# Altium schematic sheet RA_64pin_Local_Promotion_Board_4.SchDoc(RA_64pin_Local_Promotion_Board_4)
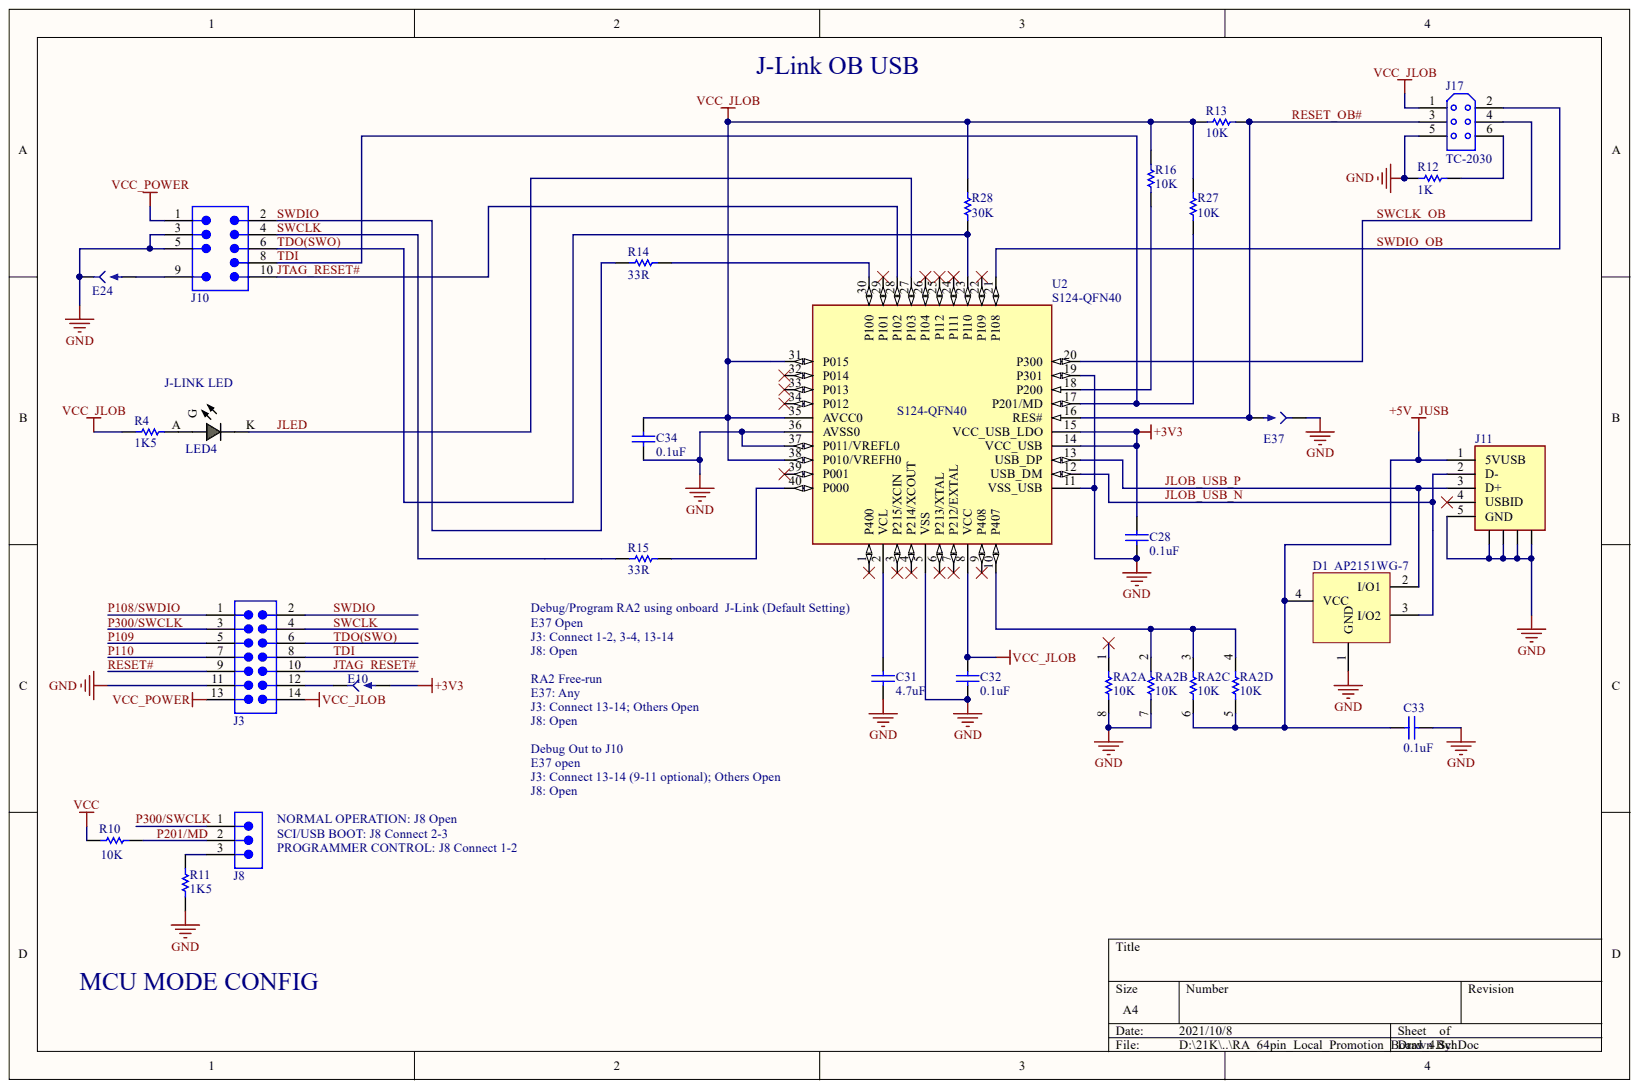
[diagram p0: RA_64pin_Local_Promotion_Board_4.SchDoc]

## Parts
C28  0.1uF  [CAPC0603-01]
C31  4.7uF  [CAPC0603-01]
C32  0.1uF  [CAPC0603-01]
C33  0.1uF  [CAPC0603-01]
C34  0.1uF  [CAPC0603-01]
D1  AP2151WG-7  [SOT-143B]
E10  Jumper Solder Bridging  [E_PadsSplit0805]  (value not drawn)  (no BOM)
E24  Jumper Solder Bridging  [E_PadsSplit0805]  (value not drawn)  (no BOM)
E37  Jumper Solder Bridging  [E_PadsSplit0805]  (value not drawn)  (no BOM)
J3  2.54mm 2*7  [HDR2X7]  (value not drawn)
J8  2.54mm 1x3  [FCI_6800-x03]  (value not drawn)
J10  1.27mm 2x5  [CON-10 C]  (value not drawn)
J11  UBAF-0608  [USBSB5_6]  (value not drawn)
J17  TC-2030  [JC-6pin-1.27 - duplicate]
LED4  #NAME?  [LC-0603_LED_S1]  (value not drawn)
R4  1K5  [RESC0603-01]
R10  10K  [RESC0603-01]
R11  1K5  [RESC0603-01]
R12  Resistance: 1K  [RESC0603-01]  (hidden comment: 4K7)
R13  Resistance: 10K  [RESC0603-01]  (hidden comment: 4K7)
R14  Resistance: 33R  [RESC0603-01]  (hidden comment: 150R)
R15  Resistance: 33R  [RESC0603-01]  (hidden comment: 150R)
R16  Resistance: 10K  [RESC0603-01]  (hidden comment: 4K7)
R27  Resistance: 10K  [RESC0603-01]  (hidden comment: 4K7)
R28  Resistance: 30K  [RESC0603-01]  (hidden comment: 4K7)
RA2  10K  [RESCAF0804]  (parts A,B,C,D)
U2  S124-QFN40  [RENESAS_P-HWQFN40-6x6-0.50]

## Nets
+3V3: C28.2 E10.2 U2.14(VCC_USB) U2.15(VCC_USB_LDO)
+5V_JUSB: C33.2 D1.4(VCC) J11.1(5VUSB) RA2.5 RA2.6
GND: C28.1 C31.1 C32.1 C33.1 C34.1 D1.1(GND) E24.1 E37.1 J3.11 J10.3 J10.5 J11.5(GND) J11.C1 J11.C2 J11.C3 J11.C4 J17.5 R11.1 R12.2 RA2.7 RA2.8 U2.5(VSS) U2.11(VSS_USB) U2.19(P301) U2.36(AVSS0) U2.37(P011/VREFL0)
VCC: R10.2
VCC_JLOB: C32.2 C34.2 J3.14 J17.1 R4.1 R13.2 R16.1 R27.1 R28.1 U2.8(VCC) U2.31(P015) U2.35(AVCC0) U2.38(P010/VREFH0)
VCC_POWER: J3.13 J10.1
JLED: LED4.K U2.27(P103)
JLOB_USB_N: D1.3(I/O2) J11.2(D-) U2.12(USB_DM)
JLOB_USB_P: D1.2(I/O1) J11.3(D+) U2.13(USB_DP)
JTAG_RESET#: J3.10 J10.10 U2.28(P102)
P108/SWDIO: J3.1
P109: J3.5
P110: J3.7
P201/MD: J8.2 R10.1
P300/SWCLK: J3.3 J8.1
RESET#: J3.9
RESET_OB#: E37.2 J17.3 R13.1 U2.16(RES#)
SWCLK: J3.4 J10.4 R15.1
SWCLK_OB: J17.4 U2.20(P300)
SWDIO: J3.2 J10.2 R14.1
SWDIO_OB: J17.2 U2.21(P108)
TDI: J3.8 J10.8 R27.2 U2.17(P201/MD)
TDO(SWO): J3.6 J10.6 R28.2 U2.23(P110)
NetC31_2: C31.2 U2.2(VCL)
NetE10_1: E10.1 J3.12
NetE24_2: E24.2 J10.9
NetJ8_3: J8.3 R11.2
NetJ17_6: J17.6 R12.1
NetLED4_A: LED4.A R4.2
NetR14_2: R14.2 U2.30(P100)
NetR15_2: R15.2 U2.40(P000)
NetR16_2: R16.2 U2.18(P200)
NetRA2_2: RA2.2 RA2.3 RA2.4 U2.10(P407)

Not connected: J11 4(USBID); RA2 1; U2 1(P400) 3(P215/XCIN) 4(P214/XCOUT) 6(P213/XTAL) 7(P212/EXTAL) 9(P408) 22(P109) 24(P111) 25(P112) 26(P104) 29(P101) 32(P014) 33(P013) 34(P012) 39(P001)

## Library data of the parts
C28 C32-C34 = CAP-0603-0.1uF: Ceramic Chip Capacitor 0603 0.1uF 50V; Manufacturer: MURATA; Mfg Part Number: GCM188L81H104KA57D; Dialectric: X8L; Tolerance: 10%; Capacitance: 0.1uF; Component Kind: Standard; Library Name: New Com.SchLib; Package: 0603; Voltage: 50V
C31 = CAP-0603-4.7uF: Ceramic Chip Capacitor 0603 4.7uF 6.3V; Manufacturer: TDK; Mfg Part Number: CGA3E1X5R0J475K080AC; Dialectric: X5R; Tolerance: 10%; Capacitance: 4.7uF; Component Kind: Standard; Package: 0603; Voltage: 6.3V; Library Name: Synergy.SchLib
D1 = DIO-PRTR5V0U2X: IC PWR SWITCH P-CHAN 1:1 SOT25; Manufacturer: Diodes; Component Kind: Standard; Library Name: RA_Design.SCHLIB; Mfg Part Number: AP2151WG-7; Package: SOT25; Part Number: AP2151WG-7
E10 E24 E37 = E_JmpSldrBridge: Pads on PCB with Narrow Gap to allow Solder Bridging; Part Type: Jumper Solder Bridging; Library Name: Synergy.SchLib
J3 = CON-2.54mm 2x7 Male: Female Header 0.1" pitch 2x7 Male; Manufacturer: Sullins; Mfg Part Number: PPTC062LFBN-RC; Positions: 14; Component Kind: Standard; Pitch: 2.54mm; Library Name: Synergy.SchLib
J8 = CON-2.54mm 1x3: Header 0.1" pitch 3pos 1x3; Manufacturer: FCI; Mfg Part Number: 77311-818-03LF; Positions: 3; Component Kind: Standard; Pitch: 0.1"; Library Name: Synergy.SchLib
J10 = CON-1.27mm 2x5 MALE SMT: Pin header,RA,male,2.54pitch,2X5p; Manufacturer: Samtec; Mfg Part Number: FTSH-105-01-L-DV-007-K; PCB Footprint: CONB132X60; Value: 2.54mm 2x5; Component Kind: Standard; Part Type: Pin header; Library Name: Synergy.SchLib
J11 = USB-UBAF-0608: Connector,MicroUSB B port,5 Position,Right Angle,Gold Flash 1u,black,SMD; Manufacturer: MTCONN; Component Kind: Standard; Mfg Part Number: UBAF-0608; Part Type: USB Connector; PCB Footprint: USBSB5_6; Value: UBAF-0608; Library Name: Synergy.SchLib
J17 = CON-TC-2030: Tag Connect TC-2030 PCB Pads 6 Pos 2x3; Component Kind: Standard; Library Name: Synergy.SchLib
LED4 = LED-000014-02: Wurth Elektronik LED Green Clear 0603; Mfg Part Number: 150060GS75000; ClassName: User-LED-G; Color: Green; Component Kind: Standard; Library Name: Semiconductors.IntLib; Manufacturer: Wurth Elektronik; Manufacturer 1: Wurth Elektronik; Manufacturer Part Number 1: #NAME?; Package: 0603; Supplier 1: Digi-Key; Supplier Part Number 1: 732-4971-1-ND
R4 R11 = RES-0603-1K5: Chip Resistor Thick Film 0603 1K5 1% 1/10W; Manufacturer: YAGEO; Mfg Part Number: RC0603FR-071K5L; Component Kind: Standard; Library Name: New Com.SchLib; Package: 0603; Power: 1/10W; Resistance: 1K5; Tolerance: 1%
R10 = RES-0603-10K: Chip Resistor Thick Film 0603 10K 1% 1/10W; Manufacturer: YAGEO; Mfg Part Number: RC0603FR-0710KL; Component Kind: Standard; Library Name: New Com.SchLib; Package: 0603; Power: 1/10W; Resistance: 10K; Tolerance: 1%
R12 R13 R16 R27 R28 = RES-0603-4K7: Chip Resistor Thick Film 0603 4K7 1% 1/10W; Manufacturer: YAGEO; Mfg Part Number: RC0603FR-074K7L; Component Kind: Standard; Library Name: New Com.SchLib; Package: 0603; Power: 1/10W; Tolerance: 1%
R14 R15 = RES-0603-150R: Chip Resistor Thick Film 0603 150R 1% 1/10W; Manufacturer: YAGEO; Mfg Part Number: RC0603FR-07150RL; Component Kind: Standard; Library Name: New Com.SchLib; Package: 0603; Power: 1/10W; Tolerance: 1%
RA2 = RES-0804-10K: Chip Resistor Array 0804 10K 5% 1/16W 4 x 0402; Manufacturer: YAGEO; Mfg Part Number: YC124-JR-0710KL; Component Kind: Standard; Package: 0804; Power: 1/16W; Resistance: 10K; Tolerance: 5%; Library Name: Synergy.SchLib
U2 = ICN-S124-QFN40: Renesas S124 32-Bit 32MHz Cortex M0+ MCU QFN40; Mfg Part Number: R7FS124773A01CNF#AC1; Architecture: 32bits; CAN: 1; Ethernet: 0; FLASH E2ROM: TBD; Component Kind: Standard; Display Name: S124-QFN40; FLASH ROM: TBD; Manufacturer: Renesas; Max Clock Speed: 32MHz; Package: QFN-40; RAM: TBD; Library Name: Synergy.SchLib
